# Altium schematic sheet PreCHG _ PreDSG.SchDoc
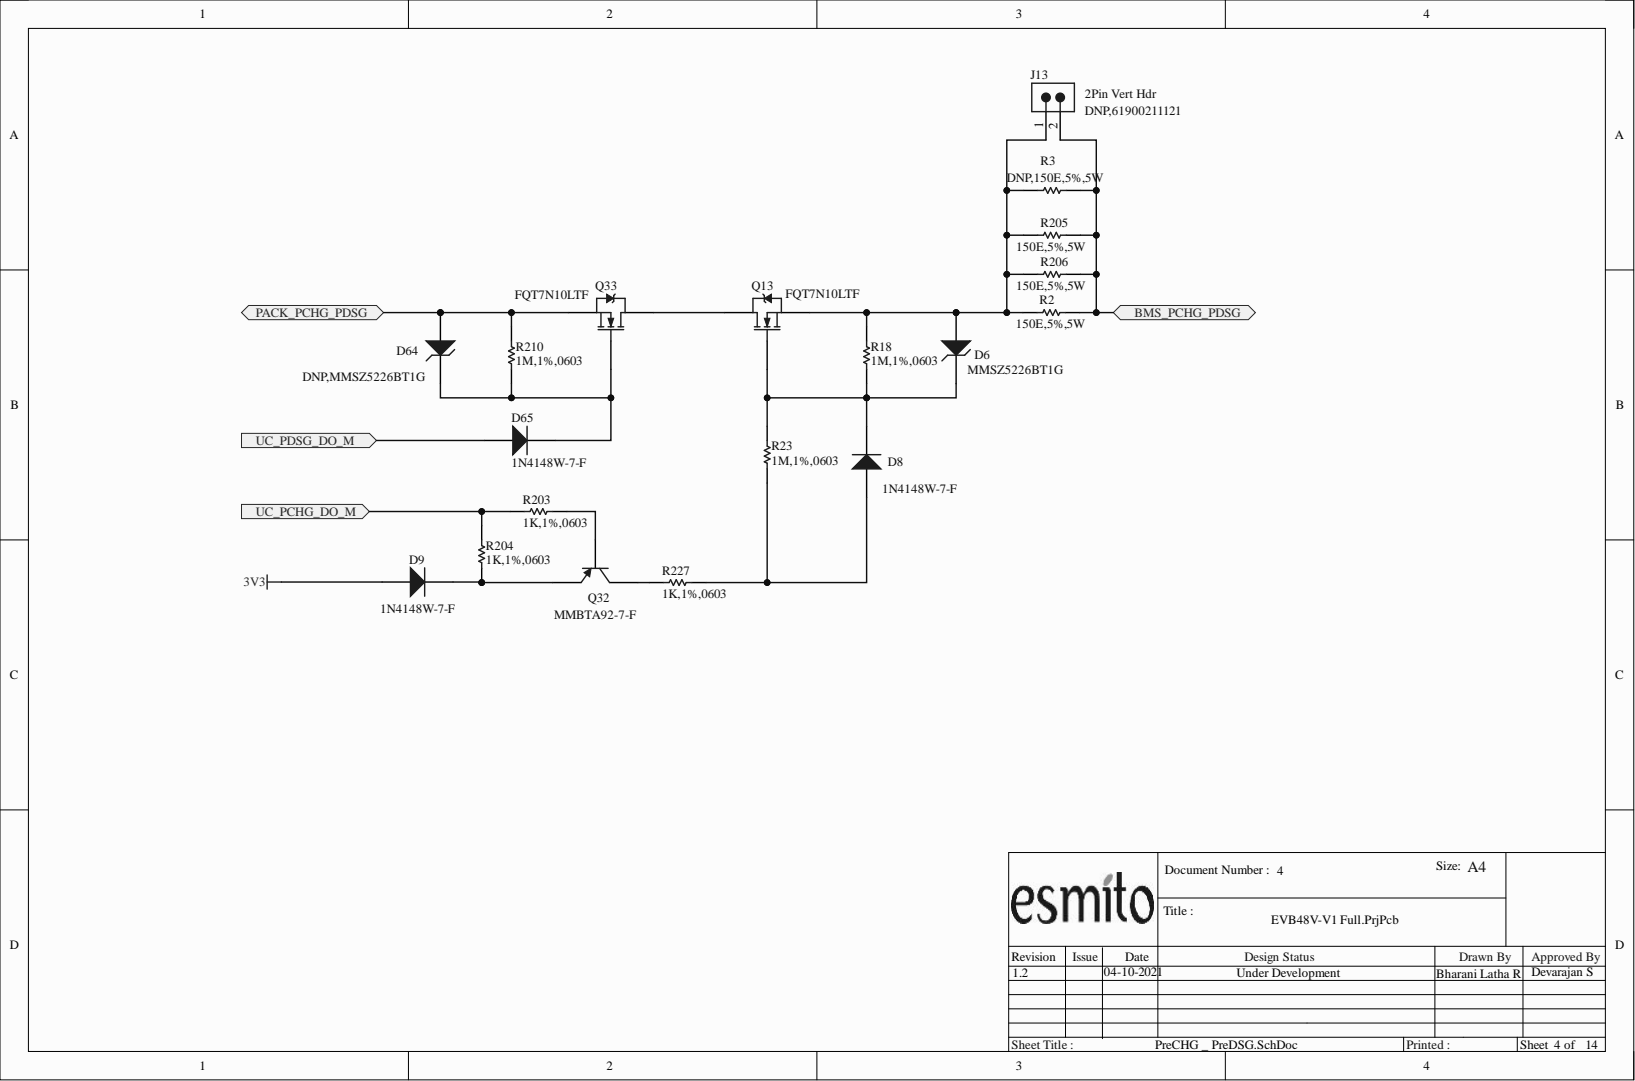
[diagram p0: PreCHG _ PreDSG.SchDoc]

## Parts
D6  value MMSZ5226BT1G  Part Number: MMSZ5226BT1G  [SOD_123_M]  (hidden comment: D_Zener)
D8  value 1N4148W-7-F  Part Number: 1N4148W-7-F  [SOD_123_M]  (hidden comment: D_Standard)
D9  value 1N4148W-7-F  Part Number: 1N4148W-7-F  [SOD_123_M]  (hidden comment: D_Standard)
D64  value DNP,MMSZ5226BT1G  [SOD_123_M]  (hidden comment: D_Zener)
D65  value 1N4148W-7-F  Part Number: 1N4148W-7-F  [SOD_123_M]  (hidden comment: D_Standard)
J13  2Pin Vert Hdr  value DNP,61900211121  [61900211121]
Q13  value FQT7N10LTF  Part Number: FQT7N10LTF  [SOT223_4_M]  (hidden comment: MOS-N_E_Power)
Q32  value MMBTA92-7-F  Part Number: MMBTA92-7-F  [SOT23_3_M]  (hidden comment: TS_PNP)
Q33  value FQT7N10LTF  Part Number: FQT7N10LTF  [SOT223_4_M]  (hidden comment: MOS-N_E_Power)
R2  value 150E,5%,5W  [RESAD22W80L1650D700_htr]  (hidden comment: R_Semi)
R3  value DNP,150E,5%,5W  [RESAD22W80L1650D700_htr]  (hidden comment: R_Semi)
R18  value 1M,1%,0603  [0603_R_M]  (hidden comment: R_Semi)
R23  value 1M,1%,0603  [0603_R_M]  (hidden comment: R_Semi)
R203  value 1K,1%,0603  [0603_R_M]  (hidden comment: R_Semi)
R204  value 1K,1%,0603  [0603_R_M]  (hidden comment: R_Semi)
R205  value 150E,5%,5W  [RESAD22W80L1650D700_htr]  (hidden comment: R_Semi)
R206  value 150E,5%,5W  [RESAD22W80L1650D700_htr]  (hidden comment: R_Semi)
R210  value 1M,1%,0603  [0603_R_M]  (hidden comment: R_Semi)
R227  value 1K,1%,0603  [0603_R_M]  (hidden comment: R_Semi)

## Ports
BMS_PCHG_PDSG, PACK_PCHG_PDSG, UC_PCHG_DO_M, UC_PDSG_DO_M

## Nets
3V3: D9.1
BMS_PCHG_PDSG: J13.2 R2.2 R3.2 R205.2 R206.2 ; port BMS_PCHG_PDSG
PACK_PCHG_PDSG: D64.1 Q33.3 R210.2 ; port PACK_PCHG_PDSG
UC_PCHG_DO_M: R203.2 R204.1 ; port UC_PCHG_DO_M
UC_PDSG_DO_M: D65.1 ; port UC_PDSG_DO_M
NetD6_1: D6.1 J13.1 Q13.3 R2.1 R3.1 R18.2 R205.1 R206.1
NetD6_2: D6.2 D8.2 Q13.1 R18.1 R23.2
NetD8_1: D8.1 R23.1 R227.2
NetD9_2: D9.2 Q32.2 R204.2
NetD64_2: D64.2 D65.2 Q33.1 R210.1
NetQ13_2: Q13.2 Q33.2
NetQ32_1: Q32.1 R203.1
NetQ32_3: Q32.3 R227.1

## Library data of the parts
D6 = D_Zener: Diode, Zener, 3.3V, 20mA, SOD123-2; Manufacturer: ON Semiconductor; Component Kind: Standard; Signal Integrity: D Zener; Simulation: ZENER; Library Name: Grinntech_Global_Library.IntLib
D8 D9 D65 = D_Standard: DIODE GEN PURP 100V 300MA SOD123; Manufacturer: Diodes Incorporated; Component Kind: Standard; Signal Integrity: Diode; Simulation: DIODE; Library Name: Grinntech_Global_Library.IntLib
D64 = D_Zener: Diode, Zener, 3.3V, 20mA, SOD123-2; Manufacturer: ON Semiconductor; Part Number: MMSZ5226BT1G; Component Kind: Standard; Signal Integrity: D Zener; Simulation: ZENER; Library Name: Grinntech_Global_Library.IntLib
J13 = 61900211121: WR-WTB THT Male Vertical Locking Header, pitch 2.54mm, 2p; Manufacturer: Wurth Elektronik; Component Kind: Standard; Part Number: 61900211121; Library Name: AFE_UC_Active_Conn.SchLib
Q13 Q33 = MOS-N_E_Power: MOSFET, N-CH, 100V, 1.7A, SOT223-4; Manufacturer: ON Semiconductor; Component Kind: Standard; Simulation: IRF1010; Library Name: Grinntech_Global_Library.IntLib
Q32 = TS_PNP: Transistor, PNP, SOT-23-3; Alternate Manufacturer: ON Semiconductor; Alternate Part Number: MMBTA92LT1G; Manufacturer: Diodes Incorporated; Component Kind: Standard; Signal Integrity: PNP; Simulation: PNP; Library Name: CLVESB-R10.SCHLIB
R2 R3 R205 R206 = R_Semi: Wirewound Resistor - Through Hole WHS High Surge 150E 5W; Manufacturer: Hi-Tech Res; Part Number: H5WI*5KV J 150R; Component Kind: Standard; Library Name: Grinntech_Global_Library.IntLib
R18 R23 R210 = R_Semi: R, THK FLM, 1M, 1%, 100mW, 0603; Manufacturer: Yageo; Part Number: RC0603FR-071ML; Component Kind: Standard; Signal Integrity: Res Semi; Simulation: RES; Library Name: Grinntech_Global_Library.IntLib
R203 R204 R227 = R_Semi: R, THK FLM, 1K, 1%, 100mW, 0603; Alternate Manufacturer: Vishay; Alternate Part Number: CRCW06031K00FKEA; Manufacturer: Yageo; Part Number: RC0603FR-071KL; Component Kind: Standard; Signal Integrity: Res Semi; Simulation: RES; Library Name: Grinntech_Global_Library.IntLib
